# Altium schematic sheet Copy of Sheet5.SchDoc
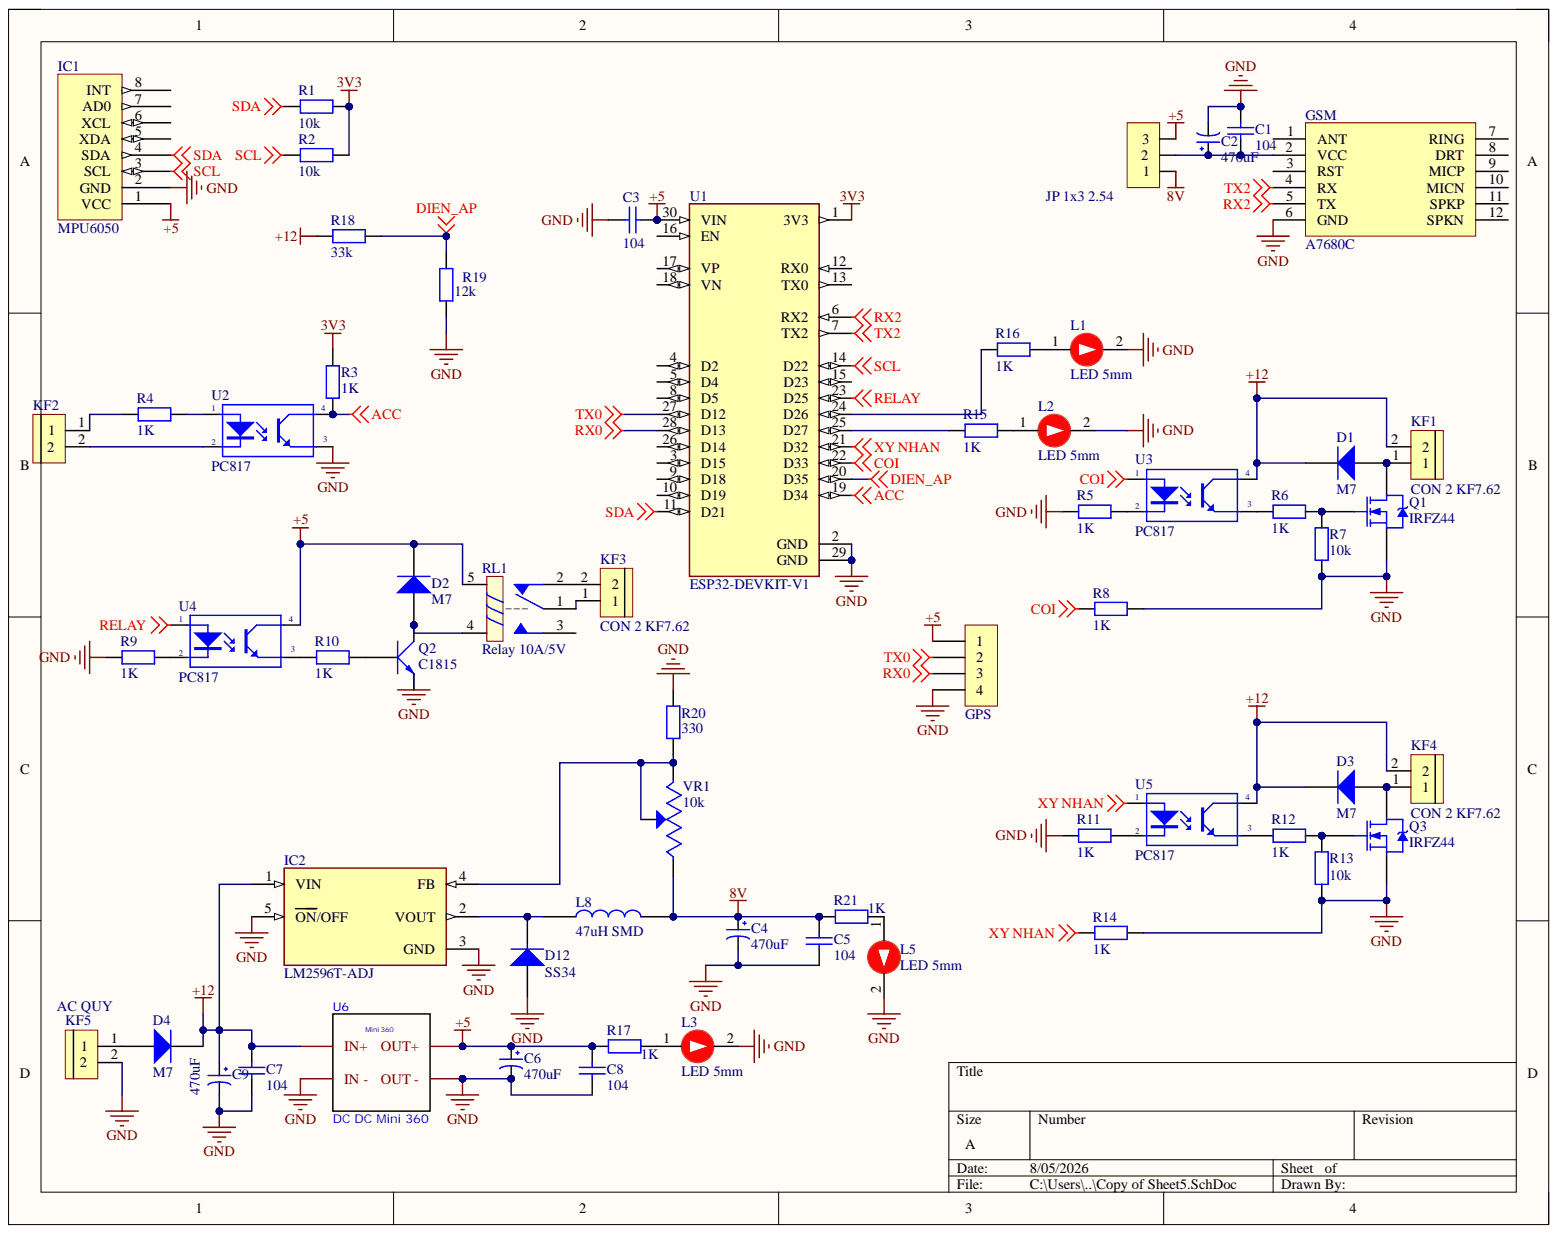
[diagram p0: Copy of Sheet5.SchDoc]

## Parts
C1  104  [tu 0805]
C2  470uF  [tu hoa 10mm]
C3  104  [tu 0805]
C4  470uF  [tu hoa 10mm]
C5  104  [tu 0805]
C6  470uF  [tu hoa 10mm]
C7  104  [tu 0805]
C8  104  [tu 0805]
C9  470uF  [tu hoa 10mm]
D1  M7  [DIODE SMA]
D2  M7  [DIODE SMA]
D3  M7  [DIODE SMA]
D4  M7  [DIODE SMA]
D12  SS34  [DIODE SMA]
GSM  A7680C  [Sim800L]
H1  GPS  [XH2.54M-4]
IC1  MPU6050  [MPU6050]
IC2  LM2596T-ADJ  [TO170P1015X457X1845-5]
JP1  JP 1x3 2.54  [JP 1x3 DT]
KF1  CON 2 KF7.62  [CON 2 KF7.62]
KF2  AC QUY  [CON 2 KF7.62]  (value not drawn)
KF3  CON 2 KF7.62  [CON 2 KF7.62]
KF4  CON 2 KF7.62  [CON 2 KF7.62]
KF5  AC QUY  [CON 2 KF7.62]
L1  LED 5mm  [LED-5MM-RED]
L2  LED 5mm  [LED-5MM-RED]
L3  LED 5mm  [LED-5MM-RED]
L5  LED 5mm  [LED-5MM-RED]
L8  47uH SMD  [Cuon Cam 7x7x5]
Q1  IRFZ44  [TO220]
Q2  C1815  [TO92]
Q3  IRFZ44  [TO220]
R1  10k  [tro smd 0805]
R2  10k  [tro smd 0805]
R3  1K  [tro smd 0805]
R4  1K  [tro smd 0805]
R5  1K  [tro smd 0805]
R6  1K  [tro smd 0805]
R7  10k  [tro smd 0805]
R8  1K  [tro smd 0805]
R9  1K  [tro smd 0805]
R10  1K  [tro smd 0805]
R11  1K  [tro smd 0805]
R12  1K  [tro smd 0805]
R13  10k  [tro smd 0805]
R14  1K  [tro smd 0805]
R15  1K  [tro smd 0805]
R16  1K  [tro smd 0805]
R17  1K  [tro smd 0805]
R18  33k  [tro smd 0805]
R19  12k  [tro smd 0805]
R20  330  [tro smd 0805]
R21  1K  [tro smd 0805]
RL1  Relay 10A/5V  [RELAY 5]
U1  ESP32-DEVKIT-V1  [MODULE_ESP32_DEVKIT_V1]
U2  PC817  [DIP4]
U3  PC817  [DIP4]
U4  PC817  [DIP4]
U5  PC817  [DIP4]
U6  DC DC Mini 360  [DC_DC_MINI360]
VR1  10k  [TRIM_3296W-1-103LF]

## Nets
3V3: R1.1 R2.2 R3.1 U1.1(3V3)
8V: C4.1 C5.2 JP1.1 L8.2 R21.2 VR1.1
+5: C3.1 C6.1 C8.2 D2.2 H1.1 IC1.1(VCC) JP1.3 R17.2 RL1.5 U1.30(VIN) U4.4 U6.1(OUT+)
+12: C7.2 C9.1 D1.2 D3.2 D4.2 IC2.1(VIN) KF1.2 KF4.2 R18.2 U3.4 U5.4 U6.3(IN+)
ACC: R3.2 U1.19(D34) U2.4
COI: R8.2 U1.22(D33) U3.1
DIEN_AP: R18.1 R19.2 U1.20(D35)
GND: C1.2 C2.2 C3.2 C4.2 C5.1 C6.2 C7.1 C8.1 C9.2 D12.1 GSM.6(GND) H1.4 IC1.2(GND) IC2.3(GND) IC2.5(ON/OFF) KF5.2 L1.2 L2.2 L3.2 L5.2 Q1.3 Q2.1 Q3.3 R5.1 R7.1 R8.1 R9.1 R11.1 R13.1 R14.1 R19.1 R20.2 U1.2(GND) U1.29(GND) U2.3 U6.2(OUT_-) U6.4(IN_-)
RELAY: U1.23(D25) U4.1
RX0: H1.3 U1.28(D13)
RX2: GSM.5(TX) U1.6(RX2)
SCL: IC1.3(SCL) R2.1 U1.14(D22)
SDA: IC1.4(SDA) R1.2 U1.11(D21)
TX0: H1.2 U1.27(D12)
TX2: GSM.4(RX) U1.7(TX2)
XY NHAN: R14.2 U1.21(D32) U5.1
NetC1_1: C1.1 C2.1 GSM.2(VCC) JP1.2
NetD1_1: D1.1 KF1.1 Q1.2
NetD2_1: D2.1 Q2.2 RL1.4
NetD3_1: D3.1 KF4.1 Q3.2
NetD4_1: D4.1 KF5.1
NetD12_2: D12.2 IC2.2(VOUT) L8.1
NetIC2_4: IC2.4(FB) R20.1 VR1.2 VR1.3
NetKF2_1: KF2.1 R4.1
NetKF2_2: KF2.2 U2.2
NetKF3_1: KF3.1 RL1.1
NetKF3_2: KF3.2 RL1.2
NetL1_1: L1.1 R16.1
NetL2_1: L2.1 R15.1
NetL3_1: L3.1 R17.1
NetL5_1: L5.1 R21.1
NetQ1_1: Q1.1 R6.2 R7.2
NetQ2_3: Q2.3 R10.2
NetQ3_1: Q3.1 R12.2 R13.2
NetR4_2: R4.2 U2.1
NetR5_2: R5.2 U3.2
NetR6_1: R6.1 U3.3
NetR9_2: R9.2 U4.2
NetR10_1: R10.1 U4.3
NetR11_2: R11.2 U5.2
NetR12_1: R12.1 U5.3
NetR15_2: R15.2 U1.25(D27)
NetR16_2: R16.2 U1.24(D26)

Not connected: GSM 1(ANT) 3(RST) 7(RING) 8(DRT) 9(MICP) 10(MICN) 11(SPKP) 12(SPKN); IC1 5(XDA) 6(XCL) 7(AD0) 8(INT); RL1 3; U1 3(D15) 4(D2) 5(D4) 8(D5) 9(D18) 10(D19) 12(RX0) 13(TX0) 15(D23) 16(EN) 17(VP) 18(VN) 26(D14)

## Library data of the parts
C1 C3 C5 C7 C8 = Tu 0805 104 50V 10%: Dán; Don_Vi: Con; Ma_Hang: MH004551; So_Chan: 2; Ten_Linh_Kien: T? 0805 104-100nF 10% 50V; Chung_Loai: Dán; Component Kind: Standard; Library Name: Tu dien.SchLib
C2 C4 C6 C9 = Tu Hoa 470uF 35V 10x15: C?m; Don_Vi: Con; Ma_Hang: MH002204; So_Chan: 2; Ten_Linh_Kien: T? Hóa 470uF 35V 10x15MM; Chung_Loai: C?m; Component Kind: Standard; Library Name: Tu dien.SchLib
D1-D4 = Diode M7 ( 4007 ): Dán; Don_Vi: Con; Ma_Hang: MH000944; Ten_Linh_Kien: Diode M7 1N4007 SMD 1A 1000V; Chung_Loai: Dán; Component Kind: Standard; Library Name: Diode.SchLib
D12 = Diode SS34 ( 1N5822 ): Dán; Don_Vi: Con; Ma_Hang: MH002104; Ten_Linh_Kien: Diode SS34 3A 40V SMA ( 1N5822 SS34A); Chung_Loai: Dán; Component Kind: Standard; Library Name: Altium_Lib_by_KhueNguyenCreator.IntLib
GSM = Sim800L: Component Kind: Standard; Library Name: Sim800L_Module.IntLib
H1 = Header 4P 2.54 Duc Thang: C?m; Don_Vi: Con; Ma_Hang: MH001285; Ten_Linh_Kien: Header 4P XH2.54-4P Ð?c Th?ng; Chung_Loai: C?m; Component Kind: Standard; Library Name: Header.SchLib
IC1 = IMU MPU6050: GY-521 6DOF IMU MPU6050  TECHMT.VN; Component Kind: Standard; Library Name: TechMT.VN_Lib_Project v2.IntLib; WEB: TECHMT.VN
IC2 = LM2596T-ADJ: 3A, 150kHz, Step-Down Switching Regulator; MF: TAEJIN; Check_prices: https://www.snapeda.com/parts/LM2596T-ADJ/TAEJIN/view-part/?ref=eda; Component Kind: Standard; Library Name: LM2596T-ADJ.IntLib; MP: LM2596T-ADJ; Package: Package; SnapEDA_Link: https://www.snapeda.com/parts/LM2596T-ADJ/TAEJIN/view-part/?ref=snap
JP1 = JP 1x3 2.54 Duc Thang: C?m; Don_Vi: Con; Ma_Hang: MH000903; Ten_Linh_Kien: Jump Ðon Ð?c 2.54MM 1x40P Th?ng 11MM; Chung_Loai: C?m; Component Kind: Standard; Library Name: Jump.SchLib
KF1 KF3 KF4 = CON 2 KF7.62: C?m; Ma_Hang: MH002053; Ten_Linh_Kien: CON 2 KF7.62-2P 7.62MM (Ðen Th?ng); Chung_Loai: C?m; Component Kind: Standard; Don_Vi: Con; Library Name: Connector.SchLib
KF2 KF5 = CON 2 KF7.62: C?m; Don_Vi: Con; Ma_Hang: MH002053; Ten_Linh_Kien: CON 2 KF7.62-2P 7.62MM (Ðen Th?ng); Chung_Loai: C?m; Component Kind: Standard; Library Name: Connector.SchLib
L1-L3 L5 = LED 5mm Do: C?m; Don_Vi: Con; Ma_Hang: MH008967; Ten_Linh_Kien: Led 5MM Ph? Ð? (1000c); Chung_Loai: C?m; Component Kind: Standard; Library Name: Altium_Lib_by_KhueNguyenCreator.IntLib
L8 = CUON CAM 47uH SMD: Dán; Don_Vi: Con; Ma_Hang: MH004211; Ten_Linh_Kien: Cu?n C?m 47uH 7x7x4MM CD74R; Chung_Loai: Dán; Component Kind: Standard; Library Name: Altium_Lib_by_KhueNguyenCreator.IntLib
Q1 Q3 = IRFZ44 DIP: N-Channel Power MOSFET; Component Kind: Standard; Library Name: TranFet.SchLib
Q2 = C1815: NPN transistor; Value: C9014; Component Kind: Standard; Library Name: TranFet.SchLib
R1-R17 R20 R21 = Tro 0805 1% 10k: Dán; Don_Vi: Con; Ma_Hang: MH005473; So_Chan: 2; Ten_Linh_Kien: Tr? 0805 1% 10k; Chung_Loai: Dán; Component Kind: Standard; Library Name: Dien Tro.SchLib
R18 R19 = Tro 0805 1% 9.1k: Dán; Don_Vi: Con; Ma_Hang: MH008813; So_Chan: 2; Ten_Linh_Kien: Tr? 0805 1% 9.1k; Chung_Loai: Dán; Component Kind: Standard; Library Name: Altium_Lib_by_KhueNguyenCreator.IntLib
RL1 = Relay 5: Relay SPDT,10A - 12VDC 5 Pin; Value: Relay SPDT, 10A, 12V; Component Kind: Standard; Library Name: TechMT.VN_Lib_Project v2.IntLib
U1 = ESP32-DEVKIT-V1: Dual core, Wi-Fi: 2.4 GHz up to 150 Mbits/s,BLE (Bluetooth Low Energy) and legacy Bluetooth, 32 bits, Up to 240 MHz; MF: Do it; Check_prices: https://www.snapeda.com/parts/ESP32-DEVKIT-V1/Do+it/view-part/?ref=eda; Component Kind: Standard; Library Name: ESP32-DEVKIT-V1 (1).IntLib; MP: ESP32-DEVKIT-V1; Package: None; SnapEDA_Link: https://www.snapeda.com/parts/ESP32-DEVKIT-V1/Do+it/view-part/?ref=snap
U2-U5 = PC817: Single Opto; Component Kind: Standard; Library Name: TechMT.VN_Lib_Project v2.IntLib; Value: PC817
VR1 = 3296W-1-103LF: Trimmer, Cermet, Rest 10 Kilohms, PCB, 25 Turns, Pwr-Rtg 0.5W, 3296 Series | Bourns 3296W-1-103LF; Check_prices: https://www.snapeda.com/parts/3296W-1-103LF/Bourns/view-part/?ref=eda; Component Kind: Standard; Library Name: 3296W-1-103LF.IntLib; MF: Bourns; MP: 3296W-1-103LF; Package: NON STANDARD-3 Bourns; SnapEDA_Link: https://www.snapeda.com/parts/3296W-1-103LF/Bourns/view-part/?ref=snap
U6 = DC DC Mini 360: Component Kind: Standard; Library Name: MINI360_BUCK.IntLib
